# Altium schematic sheet FEZ-Duino-Micro.SchDoc(FEZ-Duino-Micro)
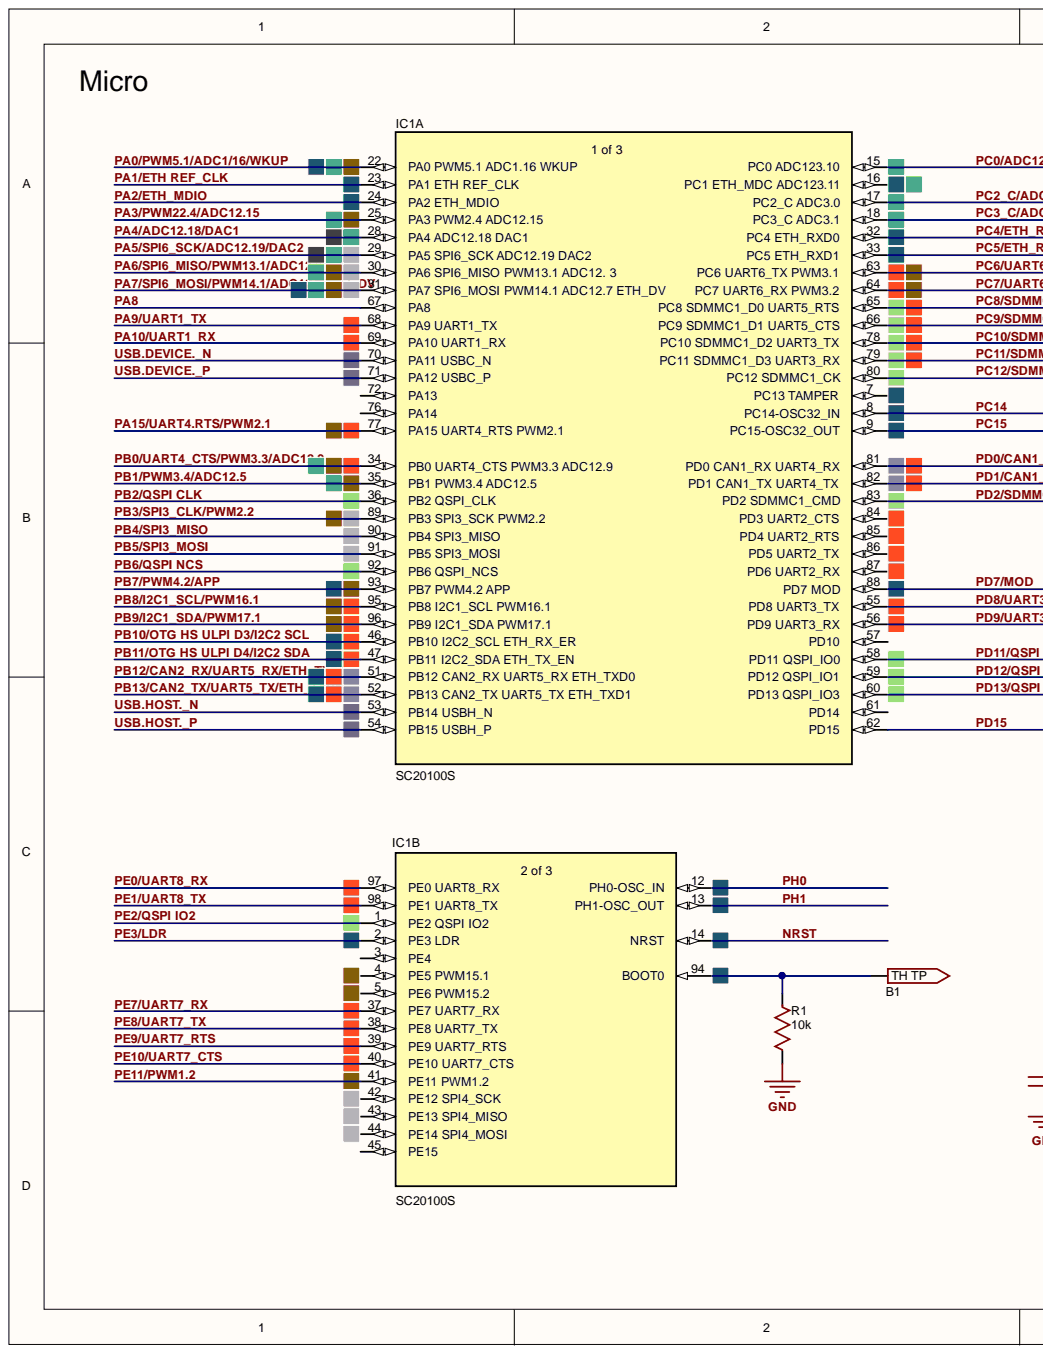
[diagram p0: FEZ-Duino-Micro.SchDoc, left]
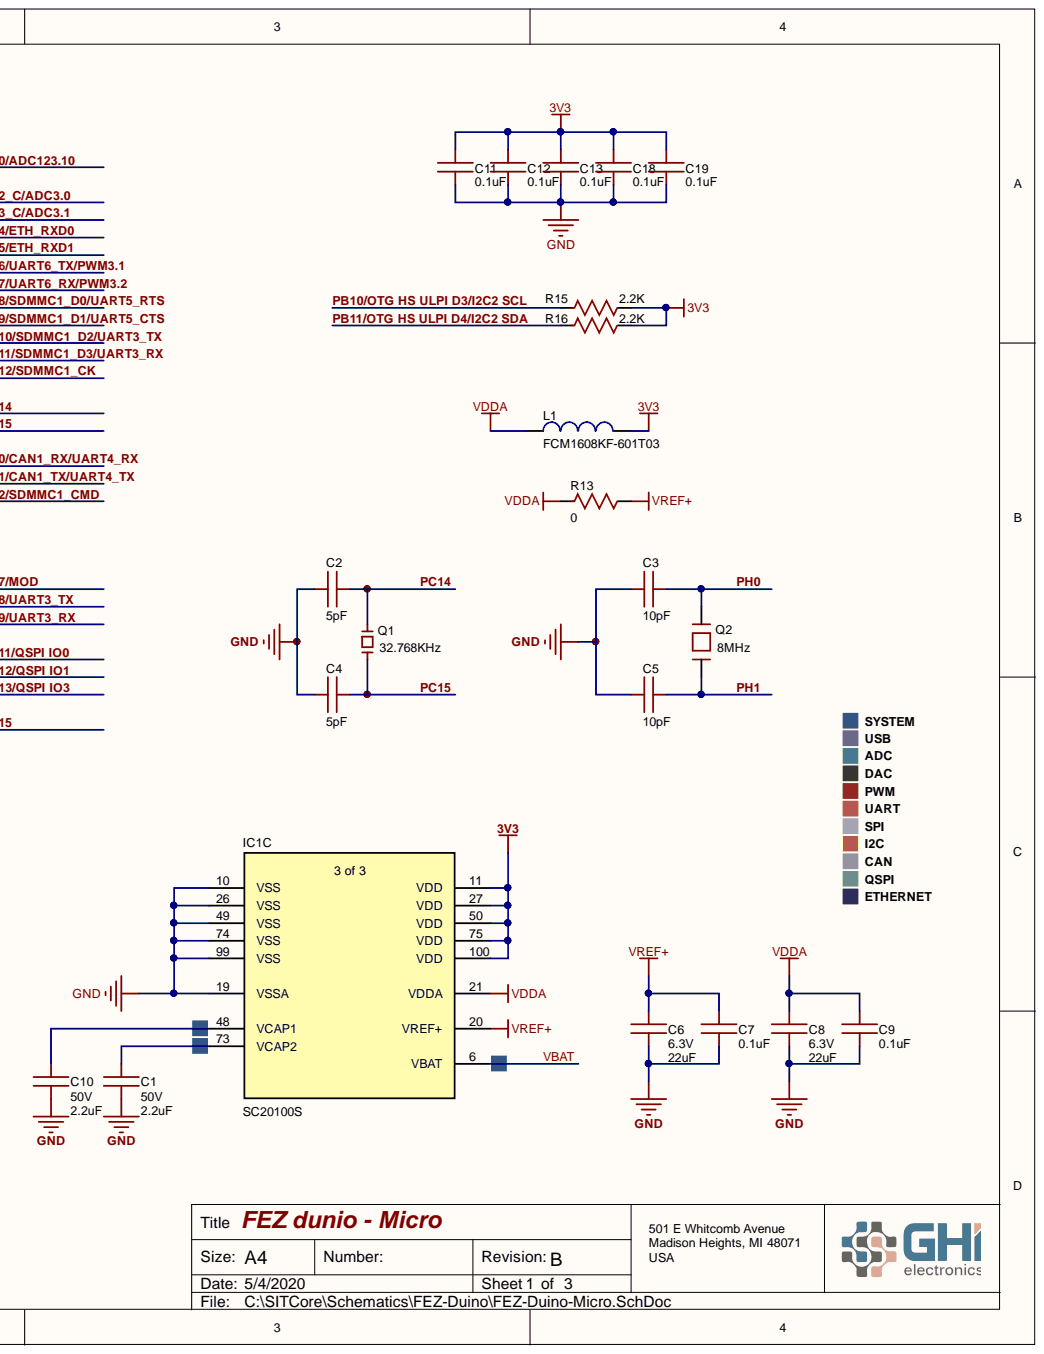
[diagram p1: FEZ-Duino-Micro.SchDoc, right]

## Parts
B1  TH Testpad 32mil (32mil ar)  [32mil TH]  (value not drawn)  (no BOM)
C1  value 2.2uF  Voltage: 50V  [C0805 L]  (hidden comment: 0805 Cap 2.2uF)
C2  value 5pF  [C0603 (1608 Metric)]  (hidden comment: 0603 Cap 5pF)
C3  value 10pF  [C0603 (1608 Metric)]  (hidden comment: 0603 Cap 10pF)
C4  value 5pF  [C0603 (1608 Metric)]  (hidden comment: 0603 Cap 5pF)
C5  value 10pF  [C0603 (1608 Metric)]  (hidden comment: 0603 Cap 10pF)
C6  value 22uF  Voltage: 6.3V  [C0805 L]  (hidden comment: 0805 Cap 22uF)
C7  value 0.1uF  [C0603 (1608 Metric)]  (hidden comment: 0603 Cap 0.1uF)
C8  value 22uF  Voltage: 6.3V  [C0805 L]  (hidden comment: 0805 Cap 22uF)
C9  value 0.1uF  [C0603 (1608 Metric)]  (hidden comment: 0603 Cap 0.1uF)
C10  value 2.2uF  Voltage: 50V  [C0805 L]  (hidden comment: 0805 Cap 2.2uF)
C11  value 0.1uF  [C0603 (1608 Metric)]  (hidden comment: 0603 Cap 0.1uF)
C12  value 0.1uF  [C0603 (1608 Metric)]  (hidden comment: 0603 Cap 0.1uF)
C13  value 0.1uF  [C0603 (1608 Metric)]  (hidden comment: 0603 Cap 0.1uF)
C18  value 0.1uF  [C0603 (1608 Metric)]  (hidden comment: 0603 Cap 0.1uF)
C19  value 0.1uF  [C0603 (1608 Metric)]  (hidden comment: 0603 Cap 0.1uF)
IC1  SC20100S  [LQFP100]  (parts A,B,C)
IC1D  SC20100S  [LQFP100]
L1  Manufacturer_Part_Number: FCM1608KF-601T03  [L0603]  (hidden comment: BEAD)
Q1  Output Frequency: 32.768KHz  [Crystal 3.2mm x 1.5mm]  (hidden comment: 32.768KHz 7pF 20ppm)
Q2  value 8MHz  [CRYSTAL_3.2X2.5]  (hidden comment: CRYSTAL3.2X2.5)
R1  value 10k  [R0603 (1608 Metric)]  (hidden comment: 0603 10K)
R13  value 0  [R0603 (1608 Metric)]  (hidden comment: 0603 Res 0)
R15  value 2.2K  [R0603 (1608 Metric)]  (hidden comment: 0603 2.2K)
R16  value 2.2K  [R0603 (1608 Metric)]  (hidden comment: 0603 2.2K)

## Nets
3V3: C11.2 C12.2 C13.2 C18.2 C19.2 IC1.11(VDD) IC1.27(VDD) IC1.50(VDD) IC1.75(VDD) IC1.100(VDD) L1.2 R15.2 R16.2
GND: C1.1 C2.1 C3.1 C4.1 C5.1 C6.1 C7.1 C8.1 C9.1 C10.1 C11.1 C12.1 C13.1 C18.1 C19.1 IC1.10(VSS) IC1.19(VSSA) IC1.26(VSS) IC1.49(VSS) IC1.74(VSS) IC1.99(VSS) R1.2
VDDA: C8.2 C9.2 IC1.21(VDDA) L1.1 R13.1
VREF+: C6.2 C7.2 IC1.20(VREF+) R13.2
NRST: IC1.14(NRST)
PA0/PWM5.1/ADC1/16/WKUP: IC1.22(PA0_PWM5.1_ADC1.16_WKUP)
PA1/ETH REF_CLK: IC1.23(PA1_ETH_REF_CLK)
PA2/ETH_MDIO: IC1.24(PA2_ETH_MDIO)
PA3/PWM22.4/ADC12.15: IC1.25(PA3_PWM2.4_ADC12.15)
PA4/ADC12.18/DAC1: IC1.28(PA4_ADC12.18_DAC1)
PA5/SPI6_SCK/ADC12.19/DAC2: IC1.29(PA5_SPI6_SCK_ADC12.19_DAC2)
PA6/SPI6_MISO/PWM13.1/ADC12.3: IC1.30
PA7/SPI6_MOSI/PWM14.1/ADC12.7/ETH_DV: IC1.31
PA8: IC1.67(PA8)
PA9/UART1_TX: IC1.68(PA9_UART1_TX)
PA10/UART1_RX: IC1.69(PA10_UART1_RX)
PA15/UART4.RTS/PWM2.1: IC1.77(PA15_UART4_RTS_PWM2.1)
PB0/UART4_CTS/PWM3.3/ADC12.9: IC1.34(PB0_UART4_CTS_PWM3.3_ADC12.9)
PB1/PWM3.4/ADC12.5: IC1.35(PB1_PWM3.4_ADC12.5)
PB2/QSPI CLK: IC1.36(PB2_QSPI_CLK)
PB3/SPI3_CLK/PWM2.2: IC1.89(PB3_SPI3_SCK_PWM2.2)
PB4/SPI3_MISO: IC1.90(PB4_SPI3_MISO)
PB5/SPI3_MOSI: IC1.91(PB5_SPI3_MOSI)
PB6/QSPI NCS: IC1.92(PB6_QSPI_NCS)
PB7/PWM4.2/APP: IC1.93(PB7_PWM4.2_APP)
PB8/I2C1_SCL/PWM16.1: IC1.95(PB8_I2C1_SCL_PWM16.1)
PB9/I2C1_SDA/PWM17.1: IC1.96(PB9_I2C1_SDA_PWM17.1)
PB10/OTG HS ULPI D3/I2C2 SCL: IC1.46(PB10_I2C2_SCL_ETH_RX_ER) R15.1
PB11/OTG HS ULPI D4/I2C2 SDA: IC1.47(PB11_I2C2_SDA_ETH_TX_EN) R16.1
PB12/CAN2_RX/UART5_RX/ETH_TXD0: IC1.51(PB12_CAN2_RX_UART5_RX_ETH_TXD0)
PB13/CAN2_TX/UART5_TX/ETH_TXD1: IC1.52(PB13_CAN2_TX_UART5_TX_ETH_TXD1)
PC0/ADC123.10: IC1.15(PC0_ADC123.10)
PC2_C/ADC3.0: IC1.17(PC2_C_ADC3.0)
PC3_C/ADC3.1: IC1.18(PC3_C_ADC3.1)
PC4/ETH_RXD0: IC1.32(PC4_ETH_RXD0)
PC5/ETH_RXD1: IC1.33(PC5_ETH_RXD1)
PC6/UART6_TX/PWM3.1: IC1.63(PC6_UART6_TX_PWM3.1)
PC7/UART6_RX/PWM3.2: IC1.64(PC7_UART6_RX_PWM3.2)
PC8/SDMMC1_D0/UART5_RTS: IC1.65(PC8_SDMMC1_D0_UART5_RTS)
PC9/SDMMC1_D1/UART5_CTS: IC1.66(PC9_SDMMC1_D1_UART5_CTS)
PC10/SDMMC1_D2/UART3_TX: IC1.78(PC10_SDMMC1_D2_UART3_TX)
PC11/SDMMC1_D3/UART3_RX: IC1.79(PC11_SDMMC1_D3_UART3_RX)
PC12/SDMMC1_CK: IC1.80(PC12_SDMMC1_CK)
PC14: C2.2 IC1.8(PC14-OSC32_IN) Q1.1
PC15: C4.2 IC1.9(PC15-OSC32_OUT) Q1.2
PD0/CAN1_RX/UART4_RX: IC1.81(PD0_CAN1_RX_UART4_RX)
PD1/CAN1_TX/UART4_TX: IC1.82(PD1_CAN1_TX_UART4_TX)
PD2/SDMMC1_CMD: IC1.83(PD2_SDMMC1_CMD)
PD7/MOD: IC1.88(PD7_MOD)
PD8/UART3_TX: IC1.55(PD8_UART3_TX)
PD9/UART3_RX: IC1.56(PD9_UART3_RX)
PD11/QSPI IO0: IC1.58(PD11_QSPI_IO0)
PD12/QSPI IO1: IC1.59(PD12_QSPI_IO1)
PD13/QSPI IO3: IC1.60(PD13_QSPI_IO3)
PD15: IC1.62(PD15)
PE0/UART8_RX: IC1.97(PE0_UART8_RX)
PE1/UART8_TX: IC1.98(PE1_UART8_TX)
PE2/QSPI IO2: IC1.1(PE2_QSPI_IO2)
PE3/LDR: IC1.2(PE3_LDR)
PE7/UART7_RX: IC1.37(PE7_UART7_RX)
PE8/UART7_TX: IC1.38(PE8_UART7_TX)
PE9/UART7_RTS: IC1.39(PE9_UART7_RTS)
PE10/UART7_CTS: IC1.40(PE10_UART7_CTS)
PE11/PWM1.2: IC1.41(PE11_PWM1.2)
PH0: C3.2 IC1.12(PH0-OSC_IN) Q2.3
PH1: C5.2 IC1.13(PH1-OSC_OUT) Q2.1
USB.DEVICE._N: IC1.70(PA11_USBC_N)
USB.DEVICE._P: IC1.71(PA12_USBC_P)
USB.HOST._N: IC1.53(PB14_USBH_N)
USB.HOST._P: IC1.54(PB15_USBH_P)
VBAT: IC1.6(VBAT)
NetB1_1: B1.1 IC1.94(BOOT0) R1.1
NetC1_2: C1.2 IC1.73(VCAP2)
NetC10_2: C10.2 IC1.48(VCAP1)

Not connected: IC1 3(PE4) 4(PE5_PWM15.1) 5(PE6_PWM15.2) 7(PC13_TAMPER) 16(PC1_ETH_MDC_ADC123.11) 42(PE12_SPI4_SCK) 43(PE13_SPI4_MISO) 44(PE14_SPI4_MOSI) 45(PE15) 57(PD10) 61(PD14) 72(PA13) 76(PA14) 84(PD3_UART2_CTS) 85(PD4_UART2_RTS) 86(PD5_UART2_TX) 87(PD6_UART2_RX)

## Library data of the parts
B1 = TH Testpad 32mil (32mil ar): TH Testpad 32mil (32mil annular ring); Library Name: GHI.SchLib
C1 C10 = 0805 Cap 2.2uF: 2.2uF ±10% 50V X5R 0805 Multilayer Ceramic Capacitors MLCC - SMD/SMT RoHS; Mfr.Part #: CL21A225KBQNNNE; Tolerance: ±10%; Package: 0805; LCSC Part #: C377773; Component Kind: Standard; Category: Ceramic; Capacitance: 2.2uF; Library Name: GHI-Passives.SchLib
C2 C4 = 0603 Cap 5pF: 10pF ±0.5pF 50V C0G 0402 Multilayer Ceramic Capacitors MLCC - SMD/SMT RoHS; Mfr.Part #: CL10C050CB8NNNC; Manufacturer: Samsung Electro-Mechanics; Tolerance: ±0.25pF; Voltage: 50V; Package: 0603; LCSC Part #: C93045; Component Kind: Standard; Category: Ceramic; Capacitance: 5pF; Library Name: GHI-Passives.SchLib
C3 C5 = 0603 Cap 10pF: 10pF ±0.5pF 50V C0G 0402 Multilayer Ceramic Capacitors MLCC - SMD/SMT RoHS; Mfr.Part #: CL10C100DB8NNNC; Manufacturer: Samsung Electro-Mechanics; Voltage: 50V; Tolerance: ±0.5pF; Package: 0603; LCSC Part #: C170139; Component Kind: Standard; Category: Ceramic; Capacitance: 10pF; Library Name: GHI-Passives.SchLib
C6 C8 = 0805 Cap 22uF: 22uF ±20% 6.3V X5R 0805 Multilayer Ceramic Capacitors MLCC - SMD/SMT RoHS; Mfr.Part #: CL21A226MQCLRNC; Tolerance: ±20%; Package: 0805; LCSC Part #: C307530; Component Kind: Standard; Category: Ceramic; Capacitance: 22uF; Library Name: GHI-Passives.SchLib
C7 C9 C11-C13 C18 C19 = 0603 Cap 0.1uF: 0.1uF ±5% 50V X7R 0603 Multilayer Ceramic Capacitors MLCC - SMD/SMT RoHS; Mfr.Part #: CL10B104JB8NNNC; Voltage: 50V; Tolerance: ±5%; Package: 0603; LCSC Part #: C24452; Digikey: 1276-1033-1-ND; Component Kind: Standard; Category: Ceramic; Capacitance: 0.1uF; Library Name: GHI-Passives.SchLib
IC1 IC1D = SC20100S: No Description Available; Manufacturer_Part_Number: stm32h7x3vit; Vendor: STMicroelectronics; Value: Value; Type: DEV; RefDes: RefDes; Component Kind: Standard; Library Name: GHI-Integrated Circuits.SchLib; Copyright: Copyright (C) 2016 Accelerated Designs. All rights reserved
L1 = (no symbol name): SMD Chip ferrite bead, 600R@100MHz +/-25%, 350mA, 500mohm DC, 0603, T=0.8mm; Part_Type: Inductor; Manufacturer: TAI-TECH; Internal_Part_Number: MCD-00047; Provided_By: Subcon; Initial_Board_Reference: MB1274; Value: 600R@100MHz; Status: Released; ECCN_US: n/a; ECCN_EU: n/a; ComponentLink1URL: http://tunmcdsvn.tun.st.com/Component_Datasheet_Library/Trunk/Inductors/TaiTech_Bead_FCM1608_series; ComponentLink1Description: TaiTech_Bead_FCM1608_series.pdf; Component Kind: Standard; Library Name: GHI.SchLib
Q1 = 32.768KHz 7pF 20ppm 2 Pad 3.2mm x 1.5mm: Crystal 2 Pad 3.2mm x 1.5mm 32.768KHz; Manufacturer Part Number: 6K30032768K0702070401; GHI Part Number: EPC-Q32KHZ; Shunt Capacitance: 1.3pF Typ; Mode: AT/Fundamental; Load Capacitance: 10 pF; Frequency Tolerance: +- 20ppm; Equivalent Series Resistance: 70k Ohm Max; Driver Level (Typical): 1uW Max; Aging @25 C 1st Year (Max): +- 3ppm/year; Storage Temperature: -55C to +125 C; Shock Resistance: N/A; Operating Temperature Range: -40 C to +85 C; Library Name: GHI.SchLib; Frequency Stability: N/A; Component Kind: Standard
Q2 = CRYSTAL3.2X2.5: 4 pad 3.2 mm x 2.5 mm Crystal; SymbolName_1: CRYSTAL.2PAD; GateName_1: G$1; LibraryName: GHI2; DeviceSetName: CRYSTAL3.2X2.5; Component Kind: Standard; Library Name: GHI.SchLib
R1 = 0603 Res 10K: 10K ±5% 1/10W ±100ppm/℃ 0603 Chip Resistor - Surface Mount RoHS; Mfr.Part #: RC0603JR-0710KL; Manufacturer: YAGEO; Voltage Rating: *V; Resistance Tolerance: ±5%; Resistance: 10k; Power Rating: 1/10W; Package: 0603; LCSC Part #: C99198; Component Kind: Standard; Library Name: GHI-Passives.SchLib
R13 = 0603 Res 0: ±5% 1/10W 0603 Chip Resistor - Surface Mount RoHS; Mfr.Part #: RC0603JR-070RL; Manufacturer: YAGEO; Voltage Rating: *V; Resistance Tolerance: ±5%; Resistance: 0; Power Rating: 1/10W; Package: 0603; LCSC Part #: C95177; Component Kind: Standard; Library Name: GHI-Passives.SchLib
R15 R16 = 0603 Res 2.2K: 2.2K ±1% 1/10W ±100ppm/℃ 0603 Chip Resistor - Surface Mount RoHS; Mfr.Part #: RC0603FR-072K2L; Manufacturer: YAGEO; Voltage Rating: *V; Resistance Tolerance: ±1%; Resistance: 2.2K; Power Rating: 1/10W; Package: 0603; LCSC Part #: C114662; Component Kind: Standard; Library Name: GHI-Passives.SchLib
